# Altium schematic sheet 12_Audio.SchDoc
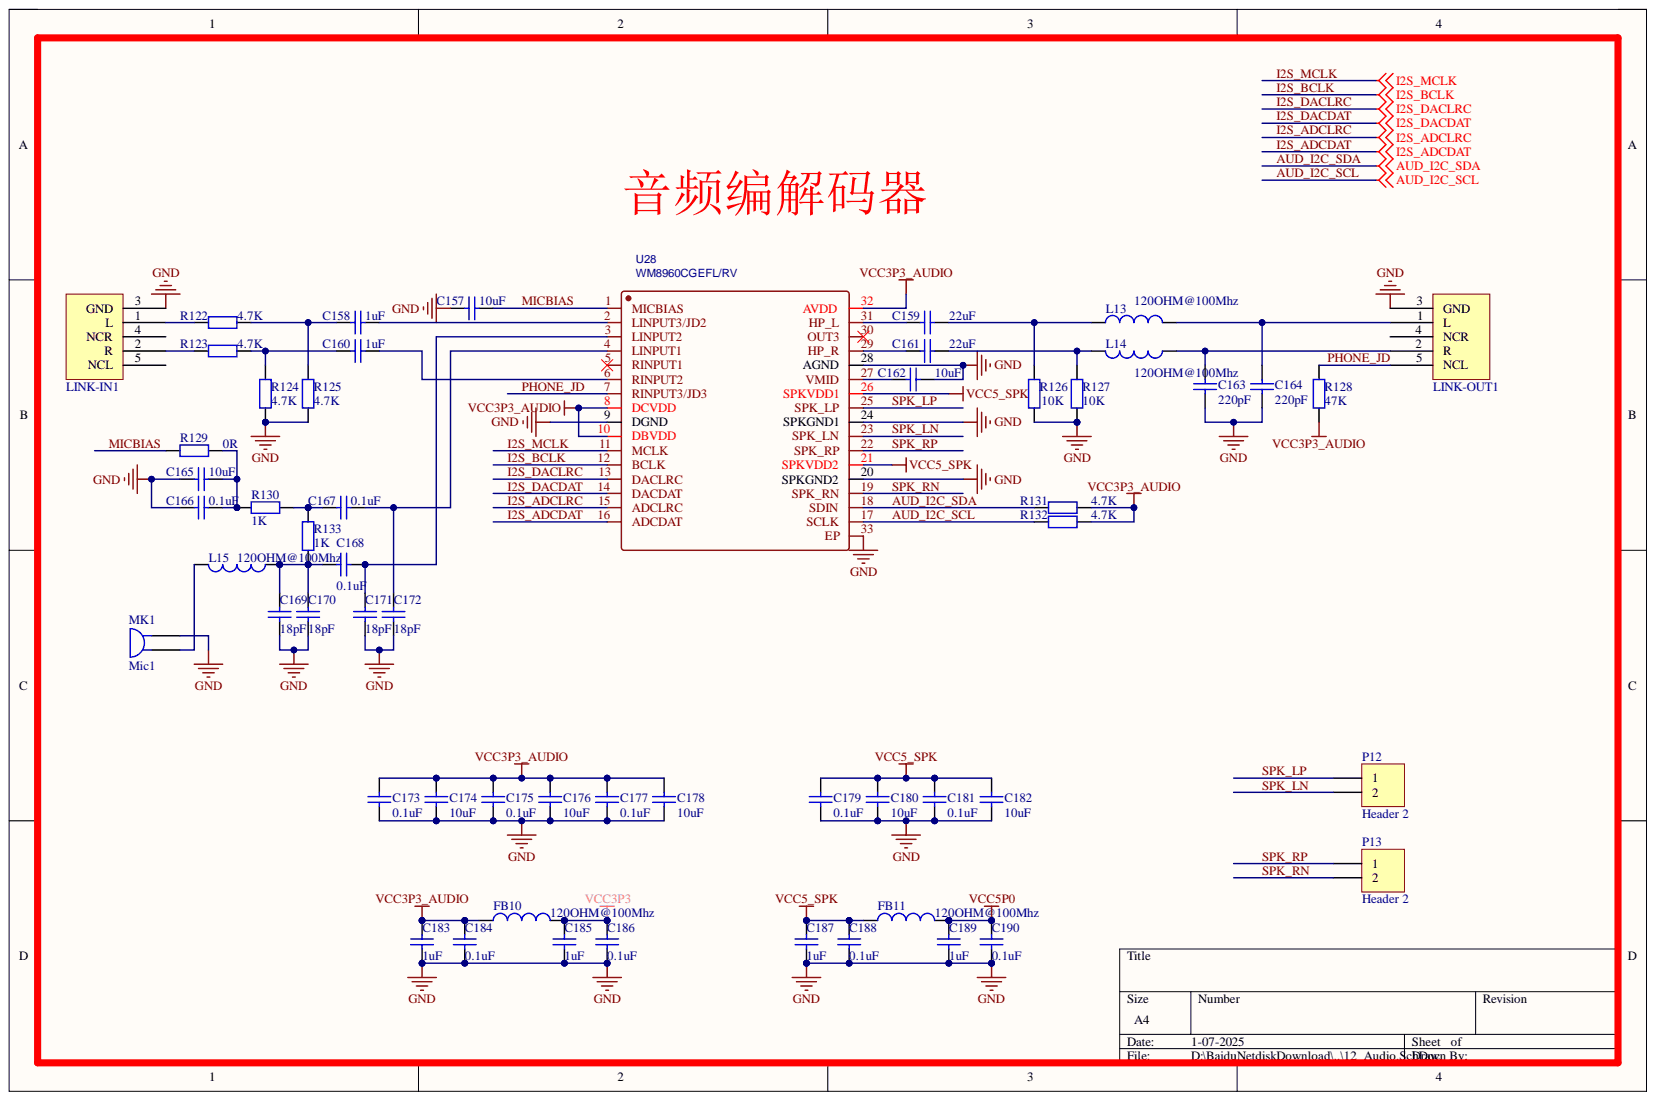
[diagram p0: 12_Audio.SchDoc]

## Parts
C157  10uF  [C0805]
C158  1uF  [C0603]
C159  22uF  [C0805]
C160  1uF  [C0603]
C161  22uF  [C0805]
C162  10uF  [C0805]
C163  220pF  [C0603]
C164  220pF  [C0603]
C165  10uF  [C0805]
C166  0.1uF  [C0603]
C167  0.1uF  [C0603]
C168  0.1uF  [C0603]
C169  18pF  [C0603]
C170  18pF  [C0603]
C171  18pF  [C0603]
C172  18pF  [C0603]
C173  0.1uF  [C0603]
C174  10uF  [C0805]
C175  0.1uF  [C0603]
C176  10uF  [C0805]
C177  0.1uF  [C0603]
C178  10uF  [C0805]
C179  0.1uF  [C0603]
C180  10uF  [C0805]
C181  0.1uF  [C0603]
C182  10uF  [C0805]
C183  1uF  [C0603]
C184  0.1uF  [C0603]
C185  1uF  [C0603]
C186  0.1uF  [C0603]
C187  1uF  [C0603]
C188  0.1uF  [C0603]
C189  1uF  [C0603]
C190  0.1uF  [C0603]
FB10  120OHM@100Mhz  [L0603]
FB11  120OHM@100Mhz  [L0603]
L13  120OHM@100Mhz  [L0603]
L14  120OHM@100Mhz  [L0603]
L15  120OHM@100Mhz  [L0603]
LINK-IN1  LI  [PJ-315-Y]
LINK-OUT1  LI  [PJ-315-PK]
MK1  Mic1  [HDR1X2]
P12  Header 2  [HDR1X2]
P13  Header 2  [HDR1X2]
R122  4.7K  [0603R]
R123  4.7K  [0603R]
R124  4.7K  [0603R]
R125  4.7K  [0603R]
R126  10K  [0603R]
R127  10K  [0603R]
R128  47K  [0603R]
R129  0R  [R0603]
R130  1K  [0603R]
R131  4.7K  [0603R]
R132  4.7K  [0603R]
R133  1K  [0603R]
U28  WM8960CGEFL/RV  [qfn-32_l5_0-w5_0-p0_50-tl-ep]

## Nets
GND: C157.2 C162.2 C163.1 C164.1 C165.1 C166.1 C169.1 C170.1 C171.1 C172.1 C173.2 C174.2 C175.2 C176.2 C177.2 C178.2 C179.2 C180.2 C181.2 C182.2 C183.1 C184.1 C185.1 C186.1 C187.1 C188.1 C189.1 C190.1 LINK-IN1.3(GND) LINK-OUT1.3(GND) MK1.2 R124.1 R125.1 R126.2 R127.2 U28.9(DGND) U28.20(SPKGND2) U28.24(SPKGND1) U28.28(AGND) U28.33(EP)
VCC3P3: C185.2 C186.2 FB10.2
VCC3P3_AUDIO: C173.1 C174.1 C175.1 C176.1 C177.1 C178.1 C183.2 C184.2 FB10.1 R128.2 R131.1 R132.1 U28.8(DCVDD) U28.10(DBVDD) U28.32(AVDD)
VCC5_SPK: C179.1 C180.1 C181.1 C182.1 C187.2 C188.2 FB11.1 U28.21(SPKVDD2) U28.26(SPKVDD1)
VCC5P0: C189.2 C190.2 FB11.2
AUD_I2C_SCL: R132.2 U28.17(SCLK)
AUD_I2C_SDA: R131.2 U28.18(SDIN)
I2S_ADCDAT: U28.16(ADCDAT)
I2S_ADCLRC: U28.15(ADCLRC)
I2S_BCLK: U28.12(BCLK)
I2S_DACDAT: U28.14(DACDAT)
I2S_DACLRC: U28.13(DACLRC)
I2S_MCLK: U28.11(MCLK)
MICBIAS: C157.1 R129.2 U28.1(MICBIAS)
PHONE_JD: LINK-OUT1.5(NCL) R128.1 U28.7(RINPUT3/JD3)
SPK_LN: P12.2 U28.23(SPK_LN)
SPK_LP: P12.1 U28.25(SPK_LP)
SPK_RN: P13.2 U28.19(SPK_RN)
SPK_RP: P13.1 U28.22(SPK_RP)
NetC158_1: C158.1 R122.2 R125.2
NetC158_2: C158.2 U28.2(LINPUT3/JD2)
NetC159_1: C159.1 U28.31(HP_L)
NetC159_2: C159.2 L13.1 R126.1
NetC160_1: C160.1 R123.2 R124.2
NetC160_2: C160.2 U28.6(RINPUT2)
NetC161_1: C161.1 U28.29(HP_R)
NetC161_2: C161.2 L14.2 R127.1
NetC162_1: C162.1 U28.27(VMID)
NetC163_2: C163.2 L14.1 LINK-OUT1.2(R)
NetC164_2: C164.2 L13.2 LINK-OUT1.1(L)
NetC165_2: C165.2 C166.2 R129.1 R130.2
NetC167_1: C167.1 R130.1 R133.2
NetC167_2: C167.2 C172.2 U28.4(LINPUT1)
NetC168_1: C168.1 C169.2 C170.2 L15.1 R133.1
NetC168_2: C168.2 C171.2 U28.3(LINPUT2)
NetL15_2: L15.2 MK1.1
NetLINK-IN1_1: LINK-IN1.1(L) R122.1
NetLINK-IN1_2: LINK-IN1.2(R) R123.1

Not connected: LINK-IN1 4(NCR) 5(NCL); LINK-OUT1 4(NCR); U28 5(RINPUT1) 30(OUT3)

## Library data of the parts
C157-C182 = Cap: Capacitor; Component Kind: Standard; LatestRevisionDate: 17-Jul-2002; LatestRevisionNote: Re-released for DXP Platform.; Library Name: Miscellaneous Devices.IntLib; PackageDescription: Radial Cap, Thru-Hole; 2 Leads; 0.3 in Pin Spacing; PackageReference: RAD-0.3; Published: 8-Jun-2000; Signal Integrity: Cap; Simulation: CAP; Publisher: Altium Limited
  C157 C162 C165 C174 C176 C178 C180 C182: Value: 10uF
  C158 C160: Value: 1uF
  C159 C161: Value: 22uF
  C163 C164: Value: 220pF
  C166-C168 C173 C175 C177 C179 C181: Value: 0.1uF
  C169-C172: Value: 18pF
C183-C190 = Cap Semi: Capacitor (Semiconductor SIM Model); Component Kind: Standard; LatestRevisionDate: 29-May-2009; LatestRevisionNote: IPC-7351 Footprint Added.; Library Name: NEW.SchLib; PackageDescription: Chip Capacitor; PackageReference: C1206; Published: 8-Jun-2000; Publisher: Altium Limited
  C183 C185 C187 C189: Value: 1uF
  C184 C186 C188 C190: Value: 0.1uF
FB10 FB11 L13-L15 = L: Inductor; Component Kind: Standard; Library Name: plm60x_v001.SchLib
LINK-IN1 = LINK-IN: Component Kind: Standard; Description: LINK-IN; Library Name: ACZ702-V2.0.SchLib
LINK-OUT1 = LINK-OUT: Component Kind: Standard; Description: LINK-OUT; Library Name: ACZ702-V2.0.SchLib
MK1 = Mic1: Microphone; Component Kind: Standard; LatestRevisionDate: 17-Jul-2002; LatestRevisionNote: Re-released for DXP Platform.; Library Name: Miscellaneous Devices.IntLib; PackageReference: PIN2; Published: 24-Mar-1999; Publisher: Altium Limited
P12 P13 = Header 2: Header, 2-Pin; Component Kind: Standard; LatestRevisionDate: 17-Jul-2002; LatestRevisionNote: Re-released for DXP Platform.; Library Name: Miscellaneous Connectors.IntLib; Signal Integrity: Connector; Publisher: Altium Limited
R122-R133 = Res2: Resistor; Component Kind: Standard; LatestRevisionDate: 17-Jul-2002; LatestRevisionNote: Re-released for DXP Platform.; PackageReference: AXIAL-0.4; Published: 8-Jun-2000; Library Name: 元件封装库.pcblib; Publisher: Altium Limited
  R122-R125 R131 R132: Value: 4.7K
  R126 R127: Value: 10K
  R128: Value: 47K
  R129: Value: 0R
  R130 R133: Value: 1K
U28 = WM8960CGEFL/RV: BOM_Manufacturer: WOLFSON; SMT Type: Extend; BOM_LCSC Assembly: Yes; BOM_Manufacturer Part: WM8960CGEFL/RV; BOM_Supplier: LCSC; BOM_Supplier Part: C18752; Component Kind: Standard; image: //image.lceda.cn/szlcsc/C18752.jpg; spicePre: U; spiceSymbolName: WM8960CGEFL/RV
